# Altium schematic sheet MCU.SchDoc
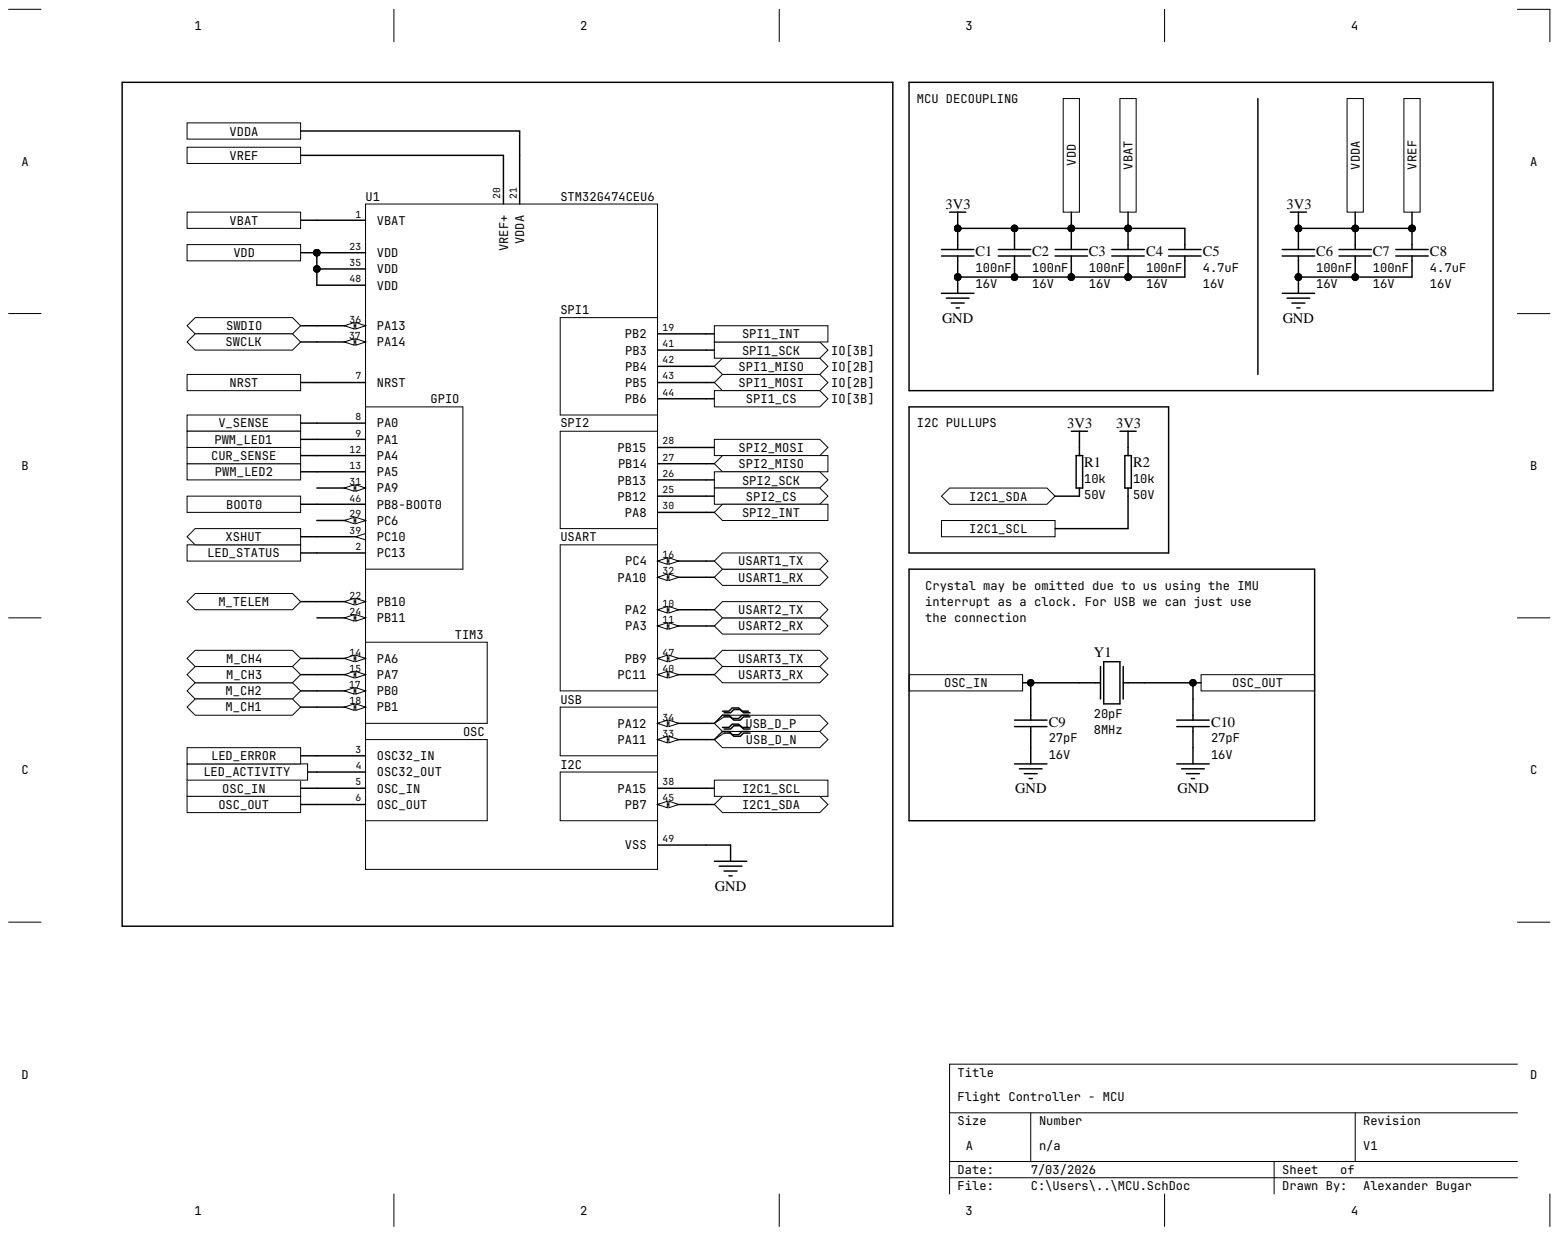
[diagram p0: MCU.SchDoc]

## Parts
C1  value 100nF  Voltage: 16V  [CAPC1005X50N]  (hidden comment: 100nF ±10% 0402 16V)
C2  value 100nF  Voltage: 16V  [CAPC1005X50N]  (hidden comment: 100nF ±10% 0402 16V)
C3  value 100nF  Voltage: 16V  [CAPC1005X50N]  (hidden comment: 100nF ±10% 0402 16V)
C4  value 100nF  Voltage: 16V  [CAPC1005X50N]  (hidden comment: 100nF ±10% 0402 16V)
C5  value 4.7uF  Voltage: 16V  [CAPC1608X80N]  (hidden comment: 4.7uF ±10% 0603 16V)
C6  value 100nF  Voltage: 16V  [CAPC1005X50N]  (hidden comment: 100nF ±10% 0402 16V)
C7  value 100nF  Voltage: 16V  [CAPC1005X50N]  (hidden comment: 100nF ±10% 0402 16V)
C8  value 4.7uF  Voltage: 16V  [CAPC1608X80N]  (hidden comment: 4.7uF ±10% 0603 16V)
C9  value 27pF  Voltage: 16V  [CAPC1005X50N]  (hidden comment: 27pF ±10% 0402 16V)
C10  value 27pF  Voltage: 16V  [CAPC1005X50N]  (hidden comment: 27pF ±10% 0402 16V)
R1  value 10k  Voltage: 50V  [RESC1005X40N]  (hidden comment: 10k 1%  50V resistor)
R2  value 10k  Voltage: 50V  [RESC1005X40N]  (hidden comment: 10k 1%  50V resistor)
U1  STM32G474CEU6  [QFN50P700X700X60-49N]
Y1  Capacitance: 20pF  Frequency: 8MHz  [XTALSM127P600-8N]  (hidden comment: 8MHz 20pF ±10ppm SMD5032-2P crystal)

## Ports
BOOT0, CUR_SENSE, I2C1_SCL, I2C1_SDA, LED_ACTIVITY, LED_ERROR, LED_STATUS, M_CH1, M_CH2, M_CH3, M_CH4, M_TELEM, NRST, OSC_IN, OSC_OUT, PWM_LED1, PWM_LED2, SPI1_CS, SPI1_INT, SPI1_MISO, SPI1_MOSI, SPI1_SCK, SPI2_CS, SPI2_INT, SPI2_MISO, SPI2_MOSI, SPI2_SCK, SWCLK, SWDIO, USART1_RX, USART1_TX, USART2_RX, USART2_TX, USART3_RX, USART3_TX, USB_D_N, USB_D_P, V_SENSE, VBAT, VDD, VDDA, VREF, XSHUT

## Nets
GND: C1.1 C2.1 C3.1 C4.1 C5.1 C6.1 C7.1 C8.1 C9.1 C10.1 U1.49(VSS)
3V3: C1.2 C2.2 C3.2 C4.2 C5.2 C6.2 C7.2 C8.2 R1.2 R2.2 U1.1(VBAT) U1.20(VREF+) U1.21(VDDA) U1.23(VDD) U1.35(VDD) U1.48(VDD) ; port VBAT, port VDD, port VDDA, port VREF
BOOT0: U1.46(PB8-BOOT0) ; port BOOT0
CUR_SENSE: U1.12(PA4) ; port CUR_SENSE
I2C1_SCL: R2.1 U1.38(PA15) ; port I2C1_SCL
I2C1_SDA: R1.1 U1.45(PB7) ; port I2C1_SDA
LED_ACTIVITY: U1.4(OSC32_OUT) ; port LED_ACTIVITY
LED_ERROR: U1.3(OSC32_IN) ; port LED_ERROR
LED_STATUS: U1.2(PC13) ; port LED_STATUS
M_CH1: U1.18(PB1) ; port M_CH1
M_CH2: U1.17(PB0) ; port M_CH2
M_CH3: U1.15(PA7) ; port M_CH3
M_CH4: U1.14(PA6) ; port M_CH4
M_TELEM: U1.22(PB10) ; port M_TELEM
NRST: U1.7(NRST) ; port NRST
OSC_IN: C9.2 U1.5(OSC_IN) Y1.1 ; port OSC_IN
OSC_OUT: C10.2 U1.6(OSC_OUT) Y1.2 ; port OSC_OUT
PWM_LED1: U1.9(PA1) ; port PWM_LED1
PWM_LED2: U1.13(PA5) ; port PWM_LED2
SPI1_CS: U1.44(PB6) ; port SPI1_CS
SPI1_INT: U1.19(PB2) ; port SPI1_INT
SPI1_MISO: U1.42(PB4) ; port SPI1_MISO
SPI1_MOSI: U1.43(PB5) ; port SPI1_MOSI
SPI1_SCK: U1.41(PB3) ; port SPI1_SCK
SPI2_CS: U1.25(PB12) ; port SPI2_CS
SPI2_INT: U1.30(PA8) ; port SPI2_INT
SPI2_MISO: U1.27(PB14) ; port SPI2_MISO
SPI2_MOSI: U1.28(PB15) ; port SPI2_MOSI
SPI2_SCK: U1.26(PB13) ; port SPI2_SCK
SWCLK: U1.37(PA14) ; port SWCLK
SWDIO: U1.36(PA13) ; port SWDIO
USART1_RX: U1.32(PA10) ; port USART1_RX
USART1_TX: U1.16(PC4) ; port USART1_TX
USART2_RX: U1.11(PA3) ; port USART2_RX
USART2_TX: U1.10(PA2) ; port USART2_TX
USART3_RX: U1.40(PC11) ; port USART3_RX
USART3_TX: U1.47(PB9) ; port USART3_TX
USB_D_N: U1.33(PA11) ; port USB_D_N
USB_D_P: U1.34(PA12) ; port USB_D_P
V_SENSE: U1.8(PA0) ; port V_SENSE
XSHUT: U1.39(PC10) ; port XSHUT

Not connected: U1 24(PB11) 29(PC6) 31(PA9)

## Library data of the parts
C1-C4 C6 C7 = CAP_0402_GENERIC: 0402 Capacitor; Manufacturer: Samsung Electro-Mechanics; Component Kind: Standard; Composition: X7R; LCSC Part Number: C1524; Package: 0402; Tolerance: ±10%; Library Name: AlexGenericSchematicLibrary.SchLib
C5 C8 = CAP_0603_GENERIC: 0603 Capacitor; Manufacturer: Samsung Electro-Mechanics; Component Kind: Standard; Composition: X7R; JLCPCB Part Number: C19666; Package: 0603; Tolerance: ±10%; Library Name: AlexGenericSchematicLibrary.SchLib
C9 C10 = CAP_0402_GENERIC: 27pF 50V C0G ±5% 0603 Multilayer Ceramic Capacitors MLCC - SMD/SMT ROHS; Manufacturer: Samsung Electro-Mechanics; Component Kind: Standard; Composition: X7R; LCSC Part Number: C1656; Package: 0402; Tolerance: ±10%; Library Name: AlexGenericSchematicLibrary.SchLib
R1 R2 = RES_0402_GENERIC: 0402 Resistor Generic; Manufacturer: UNI_ROYAL; Manufacturer Part Number: 0402WGF1002TCE; Component Kind: Standard; Tolerance: 1%; Library Name: AlexGenericSchematicLibrary.SchLib
U1 = STM32G474CEU6: -40℃~+85℃ 1.71V~3.6V 128KB 12bit 12bit 170MHz 32 Bit 38 512KB ARM Cortex-M4F Built-in FLASH UFQFPN-48(7x7) Microcontrollers (MCU/MPU/SOC) ROHS; Manufacturer: STMicroelectronics; Assembly Type: SMT Assembly; Component Kind: Standard; JLCPCB Part Number: C1235412; Manufacturer Part Number: STM32G474CEU6; Package: UFQFPN-48(7x7); Library Name: AlexGenericSchematicLibrary.SchLib
Y1 = CRYSTAL_GENERIC: Manufacturer: YXC Crystal Oscillators; Manufacturer Part Number: X50328MSB2GI; Component Kind: Standard; JLCPCB Part Number: C115962; Package: SMD5032-2P; Tolerance: ±10ppm; Library Name: AlexGenericSchematicLibrary.SchLib
